# Altium schematic sheet Sheet2.SchDoc
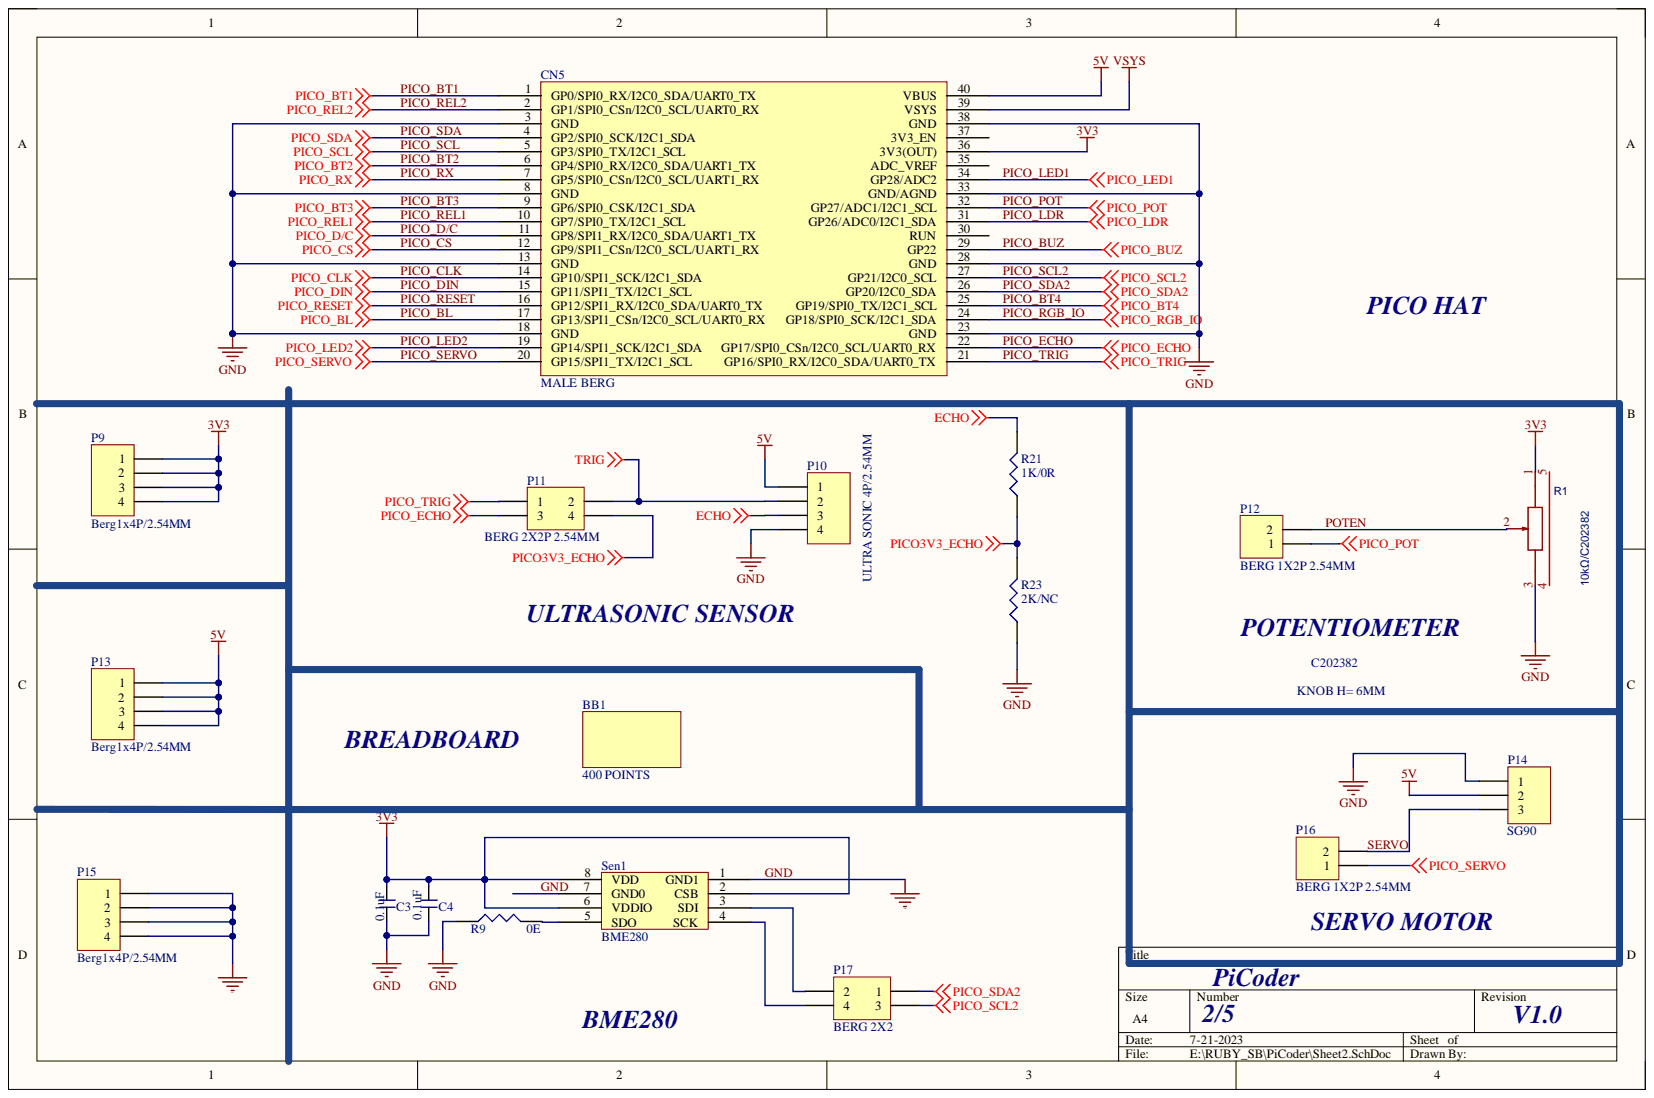
[diagram p0: Sheet2.SchDoc]

## Parts
BB1  400 POINTS  [BREADBOARD_3D]
C3  0.1uF  [C_0603]
C4  0.1uF  [C_0603]
CN5  MALE BERG  [HAT_40P_2.54MM]
P9  Berg1x4P/2.54MM  [HDR1X4]
P10  ULTRA SONIC 4P/2.54MM  [ULTRASONIC CUTOU]
P11  BERG 2X2P 2.54MM  [2X2P]
P12  BERG 1X2P 2.54MM  [2P_2.54MM]
P13  Berg1x4P/2.54MM  [HDR1X4]
P14  SG90  [servo motr]
P15  Berg1x4P/2.54MM  [HDR1X4]
P16  BERG 1X2P 2.54MM  [2P_2.54MM]
P17  BERG 2X2  [2X2P]
R1  10kΩ/C202382  [RK09K1130A5R_POT]
R9  0E  [R_0603]
R21  1K/0R  [R_0603]
R23  2K/NC  [R_0603]
Sen1  BME280  [BME28]

## Nets
3V3: C3.2 C4.2 CN5.36(3V3(OUT)) P9.1 P9.2 P9.3 P9.4 R1.1 Sen1.2(CSB) Sen1.6(VDDIO) Sen1.8(VDD)
5V: CN5.40(VBUS) P10.1 P13.1 P13.2 P13.3 P13.4 P14.2
ECHO: P10.3 R21.2
PICO3V3_ECHO: P11.4 R21.1 R23.2
TRIG: P10.2 P11.2
VSYS: CN5.39(VSYS)
GND: C3.1 C4.1 CN5.3(GND) CN5.8(GND) CN5.13(GND) CN5.18(GND) CN5.23(GND) CN5.28(GND) CN5.33(GND/AGND) CN5.38(GND) P10.4 P14.1 P15.1 P15.2 P15.3 P15.4 R1.3 R9.1 R23.1 Sen1.1(GND1) Sen1.7(GND0)
PICO_BL: CN5.17(GP13/SPI1_CSn/I2C0_SCL/UART0_RX)
PICO_BT1: CN5.1(GP0/SPI0_RX/I2C0_SDA/UART0_TX)
PICO_BT2: CN5.6(GP4/SPI0_RX/I2C0_SDA/UART1_TX)
PICO_BT3: CN5.9(GP6/SPI0_CSK/I2C1_SDA)
PICO_BT4: CN5.25(GP19/SPI0_TX/I2C1_SCL)
PICO_BUZ: CN5.29(GP22)
PICO_CLK: CN5.14(GP10/SPI1_SCK/I2C1_SDA)
PICO_CS: CN5.12(GP9/SPI1_CSn/I2C0_SCL/UART1_RX)
PICO_D/C: CN5.11(GP8/SPI1_RX/I2C0_SDA/UART1_TX)
PICO_DIN: CN5.15(GP11/SPI1_TX/I2C1_SCL)
PICO_ECHO: CN5.22(GP17/SPI0_CSn/I2C0_SCL/UART0_RX) P11.3
PICO_LDR: CN5.31(GP26/ADC0/I2C1_SDA)
PICO_LED1: CN5.34(GP28/ADC2)
PICO_LED2: CN5.19(GP14/SPI1_SCK/I2C1_SDA)
PICO_POT: CN5.32(GP27/ADC1/I2C1_SCL) P12.1
PICO_REL1: CN5.10(GP7/SPI0_TX/I2C1_SCL)
PICO_REL2: CN5.2(GP1/SPI0_CSn/I2C0_SCL/UART0_RX)
PICO_RESET: CN5.16(GP12/SPI1_RX/I2C0_SDA/UART0_TX)
PICO_RGB_IO: CN5.24(GP18/SPI0_SCK/I2C1_SDA)
PICO_RX: CN5.7(GP5/SPI0_CSn/I2C0_SCL/UART1_RX)
PICO_SCL: CN5.5(GP3/SPI0_TX/I2C1_SCL)
PICO_SCL2: CN5.27(GP21/I2C0_SCL) P17.3
PICO_SDA: CN5.4(GP2/SPI0_SCK/I2C1_SDA)
PICO_SDA2: CN5.26(GP20/I2C0_SDA) P17.1
PICO_SERVO: CN5.20(GP15/SPI1_TX/I2C1_SCL) P16.1
PICO_TRIG: CN5.21(GP16/SPI0_RX/I2C0_SDA/UART0_TX) P11.1
POTEN: P12.2 R1.2
SERVO: P14.3 P16.2
NetP17_2: P17.2 Sen1.3(SDI)
NetP17_4: P17.4 Sen1.4(SCK)
NetR9_2: R9.2 Sen1.5(SDO)

Not connected: CN5 30(RUN) 35(ADC_VREF) 37(3V3_EN); R1 4 5

## Library data of the parts
BB1 = BREADBOARD: Component Kind: Standard; Library Name: dxp_pasl_lib.IntLib
C3 C4 = CAP_NONPOLAR: Component Kind: Standard; Library Name: dxp_pasl_lib.IntLib
CN5 = CON40_PICO: Component Kind: Standard; Library Name: dxp_pasl_lib.IntLib
P9 P10 P13 P15 = Header 4: Header, 4-Pin; Component Kind: Standard; LatestRevisionDate: 17-Jul-2002; LatestRevisionNote: Re-released for DXP Platform.; Library Name: Miscellaneous Connectors.IntLib; Signal Integrity: Connector; Publisher: Altium Limited
P11 P17 = Header 2X2: Header, 2-Pin, Dual row; Component Kind: Standard; LatestRevisionDate: 17-Jul-2002; LatestRevisionNote: Re-released for DXP Platform.; Library Name: Miscellaneous Connectors.IntLib; Signal Integrity: Connector; Publisher: Altium Limited
P12 P16 = Header 2: Header, 2-Pin; Component Kind: Standard; LatestRevisionDate: 17-Jul-2002; LatestRevisionNote: Re-released for DXP Platform.; Library Name: Miscellaneous Connectors.IntLib; Signal Integrity: Connector; Publisher: Altium Limited
P14 = Header 3H: Header, 3-Pin, Right Angle; Component Kind: Standard; LatestRevisionDate: 17-Jul-2002; LatestRevisionNote: Re-released for DXP Platform.; Library Name: Miscellaneous Connectors.IntLib; Signal Integrity: Connector; Publisher: Altium Limited
R1 = POT_10kΩ: BOM_Manufacturer: ALPSALPINE(阿尔卑斯阿尔派); BOM_Manufacturer Part: RK09K1130A5R; Contributor: LCSC; nameAlias: Resistance; Resistance (Ohms): 10K; BOM_JLCPCB Part Class: Extended Part; BOM_Supplier: LCSC; BOM_Supplier Part: C209779; Component Kind: Standard; spicePre: R; spiceSymbolName: RK09K1130A5R; Library Name: MIX.SchLib
R9 R21 R23 = RES: Component Kind: Standard; Library Name: dxp_pasl_lib.IntLib
Sen1 = BME280: Digital humidity, pressure and temperature sensor 2.5mm X 2.5mm X 0.93mm metal lid LGA; Component Kind: Standard; Library Name: dxp_pasl_lib.IntLib
